# Altium schematic sheet Power.SchDoc
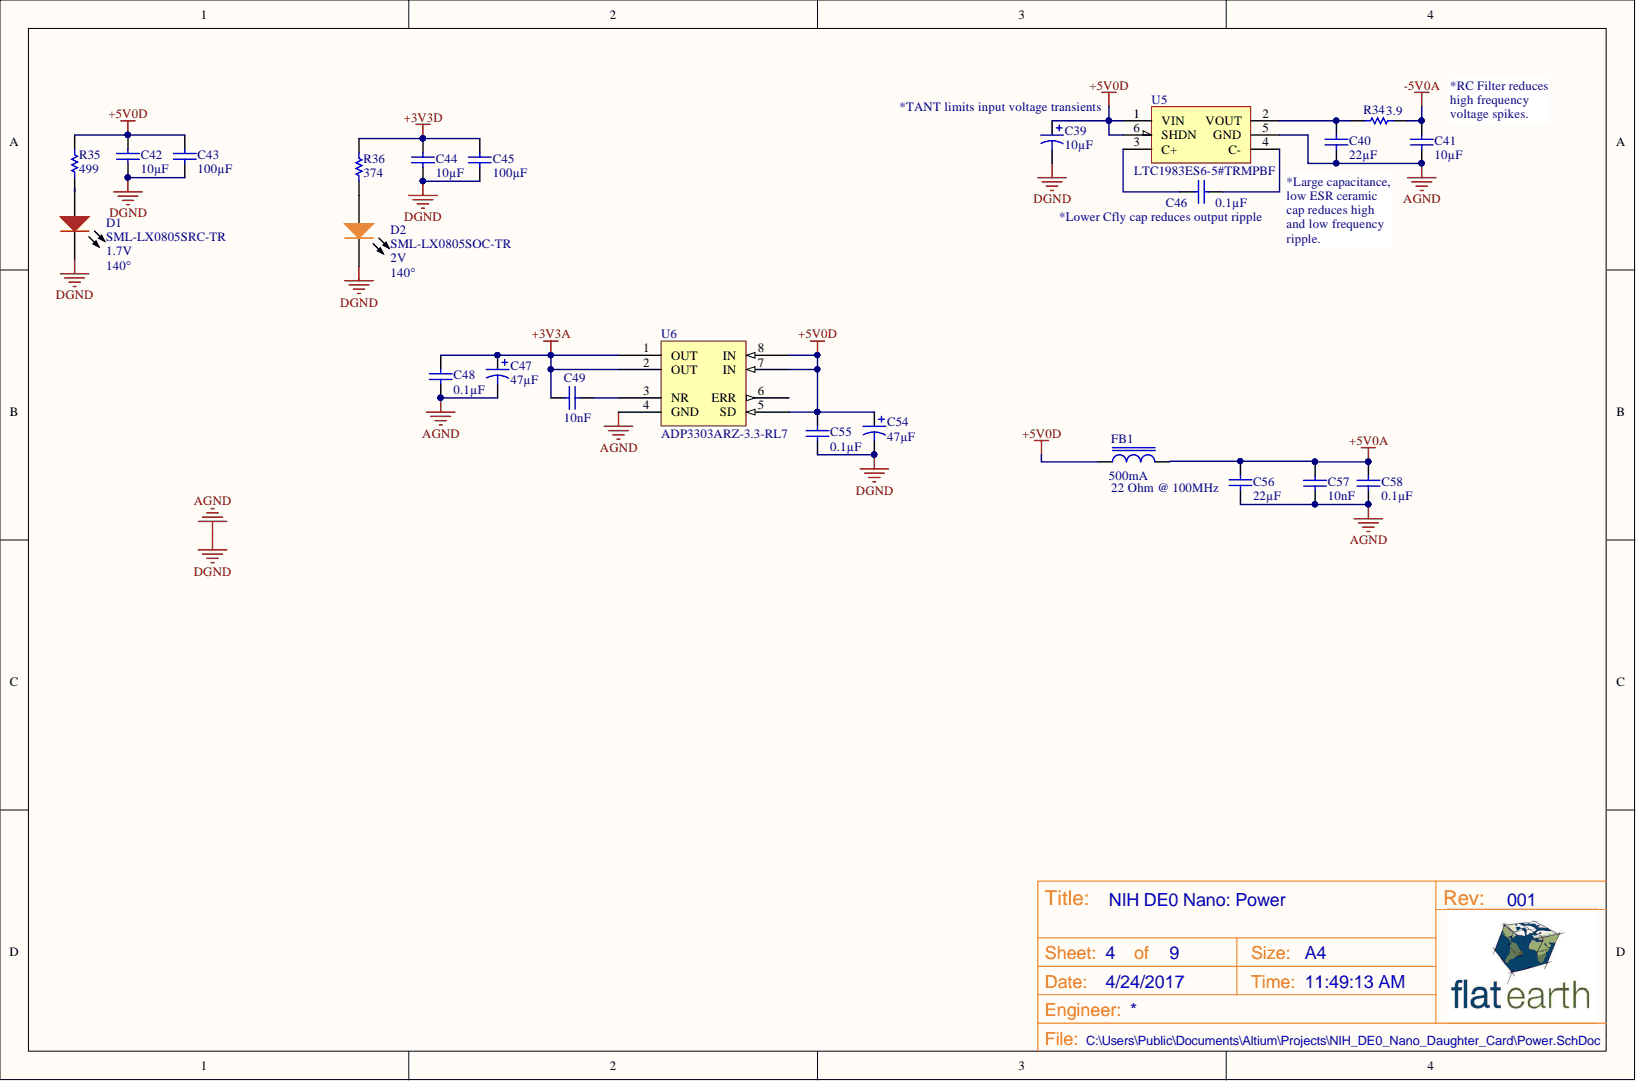
[diagram p0: Power.SchDoc]

## Parts
C39  Capacitance: 10µF  [C1206_TANT]  (hidden comment: TPSA106K010R0900)
C40  Capacitance: 22µF  [C1206]  (hidden comment: C3216X6S1C226M)
C41  Capacitance: 10µF  [3-0603_L]  (hidden comment: C1608X5R1A106K)
C42  Capacitance: 10µF  [3-0603_L]  (hidden comment: C1608X5R1A106K)
C43  Capacitance: 100µF  [C1210X25_L]  (hidden comment: CL32X107MQVNNNE)
C44  Capacitance: 10µF  [3-0603_L]  (hidden comment: C1608X5R1A106K)
C45  Capacitance: 100µF  [C1210X25_L]  (hidden comment: CL32X107MQVNNNE)
C46  Capacitance: 0.1µF  [3-0603_L]  (hidden comment: C1608X7R1H104K)
C47  Capacitance: 47µF  [ALUMCAPC630D_D]  (hidden comment: EEE-FT1H470AP)
C48  Capacitance: 0.1µF  [2-0402_L]  (hidden comment: C1005X7R1H104K)
C49  Capacitance: 10nF  [3-0603_L]  (hidden comment: C1608X7R2A103K080AA)
C54  Capacitance: 47µF  [ALUMCAPC630D_D]  (hidden comment: EEE-FT1H470AP)
C55  Capacitance: 0.1µF  [2-0402_L]  (hidden comment: C1005X7R1H104K)
C56  Capacitance: 22µF  [6-0805_L]  (hidden comment: CL21A226KPCLRNC)
C57  Capacitance: 10nF  [3-0603_L]  (hidden comment: C0603C103J5RACTU)
C58  Capacitance: 0.1µF  [3-0603_L]  (hidden comment: C1608X7R1H104K)
D1  Manufacturer Part Number: SML-LX0805SRC-TR  Viewing Angle: 140°  Voltage - Forward (Vf) (Typ): 1.7V  [DIOM2012X12L_RED]  (hidden comment: LED_RED 4)
D2  Manufacturer Part Number: SML-LX0805SOC-TR  Viewing Angle: 140°  Voltage - Forward (Vf) (Typ): 2V  [DIOM2012X12L_ORANGE]  (hidden comment: LED_ORANGE 3)
FB1  Current Rating: 500mA  [3-0603_L]  (hidden comment: BLM18BA220SN1D)
R34  Resistance (Ohms): 3.9  [3-0603_R_L]  (hidden comment: ERJ-3RQF3R9V)
R35  ERJ-3EKF4990V  [3-0603_R_L]  (value not drawn)
R36  ERJ-3EKF3740V  [3-0603_R_L]  (value not drawn)
U5  SymName  [SOT23-6_L]  (value not drawn)
U6  ADP3303ARZ-3.3-RL7  [SOIC127P600X175-8L]

## Nets
+3V3A: C47.1 C48.2 C49.1 U6.1(OUT) U6.2(OUT)
+3V3D: C44.2 C45.2 R36.2
+5V0A: C56.2 C57.2 C58.2 FB1.2
+5V0D: C39.1 C42.2 C43.2 C54.1 C55.2 FB1.1 R35.2 U5.1(VIN) U5.6(SHDN) U6.5(SD) U6.7(IN) U6.8(IN)
-5V0A: C41.2 R34.2
AGND: C39.2 C40.1 C41.1 C42.1 C43.1 C44.1 C45.1 C47.2 C48.1 C54.2 C55.1 C56.1 C57.1 C58.1 D1.2 D2.2 U5.5(GND) U6.4(GND)
NetC40_2: C40.2 R34.1 U5.2(VOUT)
NetC46_1: C46.1 U5.3(C+)
NetC46_2: C46.2 U5.4(C-)
NetC49_2: C49.2 U6.3(NR)
NetD1_1: D1.1 R35.1
NetD2_1: D2.1 R36.1

Not connected: U6 6(ERR)

## Library data of the parts
C39 = TPSA106K010R0900: CAP TANT 10UF 10V 10% 1206; Manufacturer: AVX Corporation; Manufacturer Size Code: A; ESR (Equivalent Series Resistance): 900 mOhm; Type: Molded; Category: Capacitors; Component Kind: Standard; ComponentLink1Description: http://www.avx.com/docs/Catalogs/tps.pdf; ComponentLink1URL: http://www.avx.com/docs/Catalogs/tps.pdf; Features: General Purpose; Height - Seated (Max): 0.071" (1.80mm); Lead Spacing: -; Library Name: Schematic_Components.SchLib; Lifetime @ Temp.: -; Manufacturer Part Number: TPSA106K010R0900; Mounting Type: Surface Mount; Operating Temperature: -55°C ~ 125°C; Package / Case: 1206 (3216 Metric); Packaging: Cut Tape (CT); Pricing 1: 1=0.77, 10=0.737, 100=0.3575, 250=0.297, 500=0.2695, 1000=0.2475 (USD); RoHS: RoHS Compliant; Size / Dimension: 0.126" L x 0.063" W (3.20mm x 1.60mm); Stock 1: 62197; Supplier 1: Digi-Key; Supplier Part Number 1: 478-1751-1-ND; Tolerance: ±10%; Voltage - Rated: 10V
C40 = C3216X6S1C226M: CAP CER 22UF 16V 20% X6S 1206; Manufacturer: TDK Corporation; Applications: General Purpose; Category: Capacitors; Component Kind: Standard; ComponentLink1Description: http://www.tdk.com/pdf/general_B11.pdf; ComponentLink1URL: http://www.tdk.com/pdf/general_B11.pdf; Features: -; Height - Seated (Max): -; Lead Spacing: -; Lead Style: -; Library Name: Schematic_Components.SchLib; Manufacturer Part Number: C3216X6S1C226M; Mounting Type: Surface Mount, MLCC; Operating Temperature: -55°C ~ 105°C; Package / Case: 1206 (3216 Metric); Packaging: Cut Tape (CT); Pricing 1: 1=1.13, 10=0.94, 100=0.6, 250=0.5, 500=0.45, 1000=0.41 (USD); Ratings: -; RoHS: RoHS Compliant; Size / Dimension: 0.126" L x 0.063" W (3.20mm x 1.60mm); Stock 1: 4138; Supplier 1: Digi-Key; Supplier Part Number 1: 445-8044-1-ND; Temperature Coefficient: X6S; Thickness (Max): -; Tolerance: ±20%; Voltage - Rated: 16V
C41 C42 C44 = C1608X5R1A106K: CAP CER 10UF 10V 10% X5R 0603; Manufacturer: TDK Corporation; Applications: General Purpose; Category: Capacitors; Component Kind: Standard; ComponentLink1Description: http://www.tdk.com/pdf/general_B11.pdf; ComponentLink1URL: http://www.tdk.com/pdf/general_B11.pdf; Features: -; Height - Seated (Max): -; Lead Spacing: -; Lead Style: -; Library Name: Schematic_Components.SchLib; Manufacturer Part Number: C1608X5R1A106K; Mounting Type: Surface Mount, MLCC; Operating Temperature: -55°C ~ 85°C; Package / Case: 0603 (1608 Metric); Packaging: Cut Tape (CT); Pricing 1: 1=0.48, 10=0.42, 100=0.21, 250=0.168, 500=0.153, 1000=0.138 (USD); Ratings: -; RoHS: RoHS Compliant; Size / Dimension: 0.063" L x 0.031" W (1.60mm x 0.80mm); Stock 1: 36523; Supplier 1: Digi-Key; Supplier Part Number 1: 445-7486-1-ND; Temperature Coefficient: X5R; Thickness (Max): 0.035" (0.90mm); Tolerance: ±10%; Voltage - Rated: 10V
C43 C45 = CL32X107MQVNNNE: 100µF 6.3V Ceramic Capacitor X6S 1210 (3225 Metric) 0.126" L x 0.098" W (3.20mm x 2.50mm); Manufacturer: Samsung Electro-Mechanics America, Inc.; Tolerance: ±20%; Applications: General Purpose; Category: Capacitors; Component Kind: Standard; ComponentLink1Description: http://www.samsungsem.com/kr/front/downloadcms.do?path=/kr/support/product-search/mlcc/__icsFiles/afieldfile/2014/11/05&fileName=C_CL32X107MQVNNNE.pdf; ComponentLink1URL: http://www.samsungsem.com/kr/front/downloadcms.do?path=/kr/support/product-search/mlcc/__icsFiles/afieldfile/2014/11/05&fileName=C_CL32X107MQVNNNE.pdf; Features: -; Height - Seated (Max): -; Lead Spacing: -; Lead Style: -; Library Name: Schematic_Components.SchLib; Manufacturer Part Number: CL32X107MQVNNNE; Mounting Type: Surface Mount, MLCC; Operating Temperature: -55°C ~ 105°C; Package / Case: 1210 (3225 Metric); Packaging: Cut Tape (CT); Part Status: Active; Pricing 1: 1=1.35, 10=1.13, 25=0.974, 50=0.914, 100=0.7215, 500=0.54112 (USD); Ratings: -; RoHS: RoHS Compliant; Size / Dimension: 0.126" L x 0.098" W (3.20mm x 2.50mm); Stock 1: 19666; Supplier 1: Digi-Key; Supplier Part Number 1: 1276-3399-1-ND; Temperature Coefficient: X6S; Thickness (Max): 0.110" (2.80mm); Voltage - Rated: 6.3V
C46 C58 = C1608X7R1H104K: CAP CER 0.1UF 50V 10% X7R 0603; Manufacturer: TDK Corporation; Applications: General Purpose; Category: Capacitors; Component Kind: Standard; ComponentLink1Description: http://www.tdk.com/pdf/general_B11.pdf; ComponentLink1URL: http://www.tdk.com/pdf/general_B11.pdf; Features: -; Height - Seated (Max): -; Lead Spacing: -; Lead Style: -; Library Name: Schematic_Components.SchLib; Manufacturer Part Number: C1608X7R1H104K; Mounting Type: Surface Mount, MLCC; Operating Temperature: -55°C ~ 125°C; Package / Case: 0603 (1608 Metric); Packaging: Cut Tape (CT); Pricing 1: 10=0.048, 100=0.022, 250=0.018, 500=0.0154, 1000=0.012 (USD); Ratings: -; RoHS: RoHS Compliant; Size / Dimension: 0.063" L x 0.031" W (1.60mm x 0.80mm); Stock 1: 2442296; Supplier 1: Digi-Key; Supplier Part Number 1: 445-1314-1-ND; Temperature Coefficient: X7R; Thickness (Max): 0.037" (0.95mm); Tolerance: ±10%; Voltage - Rated: 50V
C47 C54 = EEE-FT1H470AP: CAP ALUM 47UF 50V 20% SMD; Manufacturer: Panasonic - ECG; ESR (Equivalent Series Resistance): 680.0 mOhm; Lifetime @ Temp.: 2000 Hrs @ 105°C; Ripple Current: 195mA; Surface Mount Land Size: 0.260" L x 0.260" W (6.60mm x 6.60mm); Category: Capacitors; Component Kind: Standard; ComponentLink1Description: http://industrial.panasonic.com/www-cgi/jvcr17pz.cgi?E+PZ+4+ABA0134+0+WW; ComponentLink1URL: http://industrial.panasonic.com/www-cgi/jvcr17pz.cgi?E+PZ+4+ABA0134+0+WW; Features: General Purpose; Height - Seated (Max): 0.240" (6.10mm); Impedance: -; Lead Spacing: -; Library Name: Schematic_Components.SchLib; Manufacturer Part Number: EEE-FT1H470AP; Mounting Type: Surface Mount; Operating Temperature: -55°C ~ 105°C; Package / Case: Radial, Can - SMD; Packaging: Cut Tape (CT); Pricing 1: 1=0.81, 50=0.4834, 100=0.4229, 250=0.33232, 500=0.3021 (USD); RoHS: RoHS Compliant; Size / Dimension: 0.248" Dia (6.30mm); Stock 1: 1772; Supplier 1: Digi-Key; Supplier Part Number 1: PCE5023CT-ND; Tolerance: ±20%; Voltage Rating: 50V
C48 C55 = C1005X7R1H104K: CAP CER 0.1UF 50V 10% X7R 0402; Manufacturer: TDK Corporation; Applications: General Purpose; Category: Capacitors; Component Kind: Standard; ComponentLink1Description: http://www.tdk.com/pdf/general_B11.pdf; ComponentLink1URL: http://www.tdk.com/pdf/general_B11.pdf; Features: -; Height - Seated (Max): -; Lead Spacing: -; Lead Style: -; Library Name: Schematic_Components.SchLib; Manufacturer Part Number: C1005X7R1H104K; Mounting Type: Surface Mount, MLCC; Operating Temperature: -55°C ~ 125°C; Package / Case: 0402 (1005 Metric); Packaging: Cut Tape (CT); Pricing 1: 1=0.15, 10=0.1, 100=0.0475, 250=0.04, 500=0.0335, 1000=0.0275, 5000=0.02325 (USD); Ratings: -; RoHS: RoHS Compliant; Size / Dimension: 0.039" L x 0.020" W (1.00mm x 0.50mm); Stock 1: 319723; Supplier 1: Digi-Key; Supplier Part Number 1: 445-5932-1-ND; Temperature Coefficient: X7R; Thickness (Max): 0.022" (0.55mm); Tolerance: ±10%; Voltage - Rated: 50V
C49 = C1608X7R2A103K080AA: CAP CER 10000PF 100V X7R 0603; Manufacturer: TDK Corporation; Tolerance: ±10%; Applications: General Purpose; Category: Capacitors; Component Kind: Standard; ComponentLink1Description: http://product.tdk.com/capacitor/mlcc/en/documents/mlcc_commercial_midvoltage_en.pdf; ComponentLink1URL: http://product.tdk.com/capacitor/mlcc/en/documents/mlcc_commercial_midvoltage_en.pdf; Features: -; Height - Seated (Max): -; Lead Spacing: -; Lead Style: -; Library Name: Schematic_Components.SchLib; Manufacturer Part Number: C1608X7R2A103K080AA; Mounting Type: Surface Mount, MLCC; Operating Temperature: -55°C ~ 125°C; Package / Case: 0603 (1608 Metric); Packaging: Cut Tape (CT); Pricing 1: 1=0.1, 10=0.06, 100=0.0275, 250=0.02252, 500=0.01926, 1000=0.015 (USD); Ratings: -; RoHS: RoHS Compliant; Size / Dimension: 0.063" L x 0.031" W (1.60mm x 0.80mm); Stock 1: 257721; Supplier 1: Digi-Key; Supplier Part Number 1: 445-1304-1-ND; Temperature Coefficient: X7R; Thickness (Max): 0.037" (0.95mm); Voltage - Rated: 100V
C56 = CL21A226KPCLRNC: CAP CER 22UF 10V X5R 0805; Manufacturer: Samsung Electro-Mechanics America, Inc.; Tolerance: ±10%; Applications: General Purpose; Category: Capacitors; Component Kind: Standard; ComponentLink1Description: http://www.samsungsem.com/kr/support/product-search/mlcc/__icsFiles/afieldfile/2016/08/18/S_CL21A226KPCLRNC.pdf; ComponentLink1URL: http://www.samsungsem.com/kr/support/product-search/mlcc/__icsFiles/afieldfile/2016/08/18/S_CL21A226KPCLRNC.pdf; Features: Low Profile; Height - Seated (Max): -; Lead Spacing: -; Lead Style: -; Library Name: Schematic_Components.SchLib; Manufacturer Part Number: CL21A226KPCLRNC; Mounting Type: Surface Mount, MLCC; Operating Temperature: -55°C ~ 85°C; Package / Case: 0805 (2012 Metric); Packaging: Cut Tape (CT); Part Status: Active; Pricing 1: 1=0.25, 10=0.173, 25=0.1384, 50=0.1154, 100=0.0981, 250=0.07788, 500=0.06924, 1000=0.06059 (USD); Ratings: -; RoHS: RoHS Compliant; Size / Dimension: 0.079" L x 0.049" W (2.00mm x 1.25mm); Stock 1: 70317; Supplier 1: Digi-Key; Supplier Part Number 1: 1276-6786-1-ND; Temperature Coefficient: X5R; Thickness (Max): 0.037" (0.95mm); Voltage - Rated: 10V
C57 = C0603C103J5RACTU: CAP CER 10000PF 50V 5% X7R 0603; Manufacturer: Kemet; Applications: General Purpose; Category: Capacitors; Component Kind: Standard; ComponentLink1Description: http://www.kemet.com/datasheets&C0603C103J5RACTU; ComponentLink1URL: http://www.kemet.com/datasheets&C0603C103J5RACTU; Features: -; Height - Seated (Max): -; Lead Spacing: -; Lead Style: -; Library Name: Schematic_Components.SchLib; Manufacturer Part Number: C0603C103J5RACTU; Mounting Type: Surface Mount, MLCC; Operating Temperature: -55°C ~ 125°C; Package / Case: 0603 (1608 Metric); Packaging: Cut Tape (CT); Pricing 1: 1=0.1, 10=0.036, 50=0.0196, 100=0.0165, 250=0.01352, 500=0.01156, 1000=0.009 (USD); Ratings: -; RoHS: RoHS Compliant; Size / Dimension: 0.063" L x 0.031" W (1.60mm x 0.80mm); Stock 1: 187680; Supplier 1: Digi-Key; Supplier Part Number 1: 399-1092-1-ND; Temperature Coefficient: X7R; Thickness (Max): 0.034" (0.87mm); Tolerance: ±5%; Voltage - Rated: 50V
D1 = LED_RED 4: LED 660NM SUPER RED CLR 0805 T/R; Manufacturer: Lumex Opto/Components Inc; Current - Test: 20mA; Lens Type: Clear; Millicandela Rating: 25mcd; Category: Optoelectronics; Color: Red; Component Kind: Standard; ComponentLink1Description: http://www.lumex.com/specs/SML-LX0805SRC-TR.pdf; ComponentLink1URL: http://www.lumex.com/specs/SML-LX0805SRC-TR.pdf; Height: 1.10mm; Library Name: Schematic_Components.SchLib; Luminous Flux @ Current - Test: -; Mounting Type: Surface Mount; Package / Case: 0805 (2012 Metric); Packaging: Cut Tape (CT); Pricing 1: 1=0.56, 10=0.395, 100=0.2596, 250=0.19472, 500=0.177, 1000=0.1416 (USD); RoHS: RoHS Compliant; Size / Dimension: 2.00mm L x 1.25mm W; Stock 1: 1141; Supplier 1: Digi-Key; Supplier Part Number 1: 67-2191-1-ND; Wavelength - Dominant: -; Wavelength - Peak: 660nm
D2 = LED_ORANGE 3: LED 610NM SUPER ORANGE 0805 SMD; Manufacturer: Lumex Opto/Components Inc; Current - Test: 20mA; Lens Style/Size: Rectangle with Flat Top, 1.4mm x 1.25mm; Lens Type: Clear; Millicandela Rating: 60mcd; Category: Optoelectronics; Color: Orange; Component Kind: Standard; ComponentLink1Description: http://www.lumex.com/specs/SML-LX0805SOC-TR.pdf; ComponentLink1URL: http://www.lumex.com/specs/SML-LX0805SOC-TR.pdf; Height: 1.10mm; Library Name: Schematic_Components.SchLib; Luminous Flux @ Current - Test: -; Mounting Type: Surface Mount; Package / Case: 0805 (2012 Metric); Packaging: Cut Tape (CT); Pricing 1: 1=0.65, 10=0.502, 100=0.3255, 250=0.279, 500=0.2418, 1000=0.186 (USD); RoHS: RoHS Compliant; Size / Dimension: 2.00mm L x 1.25mm W; Stock 1: 13373; Supplier 1: Digi-Key; Supplier Part Number 1: 67-1637-1-ND; Wavelength - Dominant: -; Wavelength - Peak: 610nm
FB1 = BLM18BA220SN1D: FERRITE CHIP 22 OHM 500MA 0603; Manufacturer: Murata Electronics North America; DC Resistance (DCR): 350 mOhm Max; Filter Type: Differential Mode - Single; Impedance @ Frequency: 22 Ohm @ 100MHz; Category: Filters; Component Kind: Standard; ComponentLink1Description: http://search.murata.co.jp/Ceramy/image/img/PDF/ENG/L0110S0100BLM18B.pdf; ComponentLink1URL: http://search.murata.co.jp/Ceramy/image/img/PDF/ENG/L0110S0100BLM18B.pdf; Height (Max): 0.037" (0.95mm); Library Name: Schematic_Components.SchLib; Manufacturer Part Number: BLM18BA220SN1D; Mounting Type: Surface Mount; Package / Case: 0603 (1608 Metric); Packaging: Cut Tape (CT); Pricing 1: 1=0.24, 10=0.18, 50=0.105, 100=0.084, 250=0.06, 500=0.0408, 1000=0.033 (USD); RoHS: RoHS Compliant; Size / Dimension: 0.063" L x 0.031" W (1.60mm x 0.80mm); Stock 1: 10969; Supplier 1: Digi-Key; Supplier Part Number 1: 490-1016-1-ND
R34 = ERJ-3RQF3R9V: RES 3.9 OHM 1/10W 1% 0603 SMD; Manufacturer: Panasonic Electronic Components; Power (Watts): 0.1W, 1/10W; Temperature Coefficient: ±200ppm/°C; Category: Resistors; Component Kind: Standard; ComponentLink1Description: http://industrial.panasonic.com/www-cgi/jvcr13pz.cgi?E+PZ+3+AOA0003+ERJ3RQF3R9V+7+WW; ComponentLink1URL: http://industrial.panasonic.com/www-cgi/jvcr13pz.cgi?E+PZ+3+AOA0003+ERJ3RQF3R9V+7+WW; Composition: Thick Film; Features: -; Height: 0.022" (0.55mm); Library Name: Schematic_Components.SchLib; Manufacturer Part Number: ERJ-3RQF3R9V; Number of Terminations: 2; Package / Case: 0603 (1608 Metric); Packaging: Cut Tape (CT); Pricing 1: 1=0.29, 50=0.1138, 100=0.0878, 250=0.06824, 500=0.0481, 1000=0.0351 (USD); RoHS: RoHS Compliant; Size / Dimension: 0.063" L x 0.031" W (1.60mm x 0.80mm); Stock 1: 44573; Supplier 1: Digi-Key; Supplier Device Package: 0603; Supplier Part Number 1: P3.9AJCT-ND; Tolerance: ±1%
R35 = ERJ-3EKF4990V: RES 499 OHM 1/10W 1% 0603 SMD; Manufacturer: Panasonic - ECG; Power (Watts): 0.1W, 1/10W; Resistance (Ohms): 499; Temperature Coefficient: ±100ppm/°C; Category: Resistors; Component Kind: Standard; ComponentLink1Description: http://industrial.panasonic.com/www-cgi/jvcr13pz.cgi?E+PZ+3+AOA0002+ERJ3EKF4990V+7+WW; ComponentLink1URL: http://industrial.panasonic.com/www-cgi/jvcr13pz.cgi?E+PZ+3+AOA0002+ERJ3EKF4990V+7+WW; Composition: Thick Film; Features: -; Height: 0.022" (0.55mm); Library Name: Schematic_Components.SchLib; Manufacturer Part Number: ERJ-3EKF4990V; Number of Terminations: 2; Package / Case: 0603 (1608 Metric); Packaging: Cut Tape (CT); Pricing 1: 1=0.1, 50=0.0138, 100=0.0105, 250=0.0078, 500=0.00576, 1000=0.00384 (USD); RoHS: RoHS Compliant; Size / Dimension: 0.063" L x 0.031" W (1.60mm x 0.80mm); Stock 1: 748630; Supplier 1: Digi-Key; Supplier Part Number 1: P499HCT-ND; Tolerance: ±1%
R36 = ERJ-3EKF3740V: RES SMD 374 OHM 1% 1/10W 0603; Manufacturer: Panasonic Electronic Components; Power (Watts): 0.1W, 1/10W; Resistance (Ohms): 374; Temperature Coefficient: ±100ppm/°C; Category: Resistors; Component Kind: Standard; ComponentLink1Description: http://industrial.panasonic.com/www-cgi/jvcr13pz.cgi?E+PZ+3+AOA0002+ERJ3EKF3740V+7+WW; ComponentLink1URL: http://industrial.panasonic.com/www-cgi/jvcr13pz.cgi?E+PZ+3+AOA0002+ERJ3EKF3740V+7+WW; Composition: Thick Film; Features: Automotive AEC-Q200; Height: 0.022" (0.55mm); Library Name: Schematic_Components.SchLib; Manufacturer Part Number: ERJ-3EKF3740V; Number of Terminations: 2; Operating Temperature: -55°C ~ 155°C; Package / Case: 0603 (1608 Metric); Packaging: Cut Tape (CT); Part Status: Active; Pricing 1: 1=0.1, 50=0.0114, 100=0.0084, 250=0.00644, 500=0.00514, 1000=0.00379 (USD); RoHS: RoHS Compliant; Size / Dimension: 0.063" L x 0.031" W (1.60mm x 0.80mm); Stock 1: 48031; Supplier 1: Digi-Key; Supplier Device Package: 0603; Supplier Part Number 1: P374HCT-ND; Tolerance: ±1%
U5 = LTC1983ES6-5#TRMPBF: IC REG SWITCHD CAP INV -5V SOT23; Manufacturer: Linear Technology; Current - Output: 100mA; Frequency - Switching: 900kHz; Number of Outputs: 1; Output Type: Fixed; PWM Type: Burst Mode®; Synchronous Rectifier: No; Voltage - Input: 2.3 V ~ 5.5 V; Category: Integrated Circuits (ICs); Component Kind: Standard; ComponentLink1Description: http://www.linear.com/docs/2135; ComponentLink1URL: http://www.linear.com/docs/2135; Library Name: Schematic_Components.SchLib; Manufacturer Part Number: LTC1983ES6-5#TRMPBF; Mounting Type: Surface Mount; Operating Temperature: -40°C ~ 85°C; Package / Case: SOT-23-6 Thin, TSOT-23-6; Packaging: Cut Tape (CT); Pricing 1: 1=4.28, 25=3.8192, 100=3.4371 (USD); RoHS: RoHS Compliant; Stock 1: 6466; Supplier 1: Digi-Key; Supplier Device Package: TSOT-23-6; Supplier Part Number 1: LTC1983ES6-5#TRMPBFCT-ND; Type: Switched Capacitor (Charge Pump), Inverting; Voltage - Output: -5V
U6 = ADP3303ARZ-3.3-RL7: Linear Voltage Regulator IC Positive Fixed 1 Output 3.3V 200mA 8-SOIC; Manufacturer: Analog Devices Inc.; Number of Regulators: 1; Voltage - Dropout (Typical): 0.18V @ 200mA; Voltage - Input: Up to 12V; Category: Integrated Circuits (ICs); Component Kind: Standard; ComponentLink1Description: http://www.analog.com/media/en/technical-documentation/data-sheets/ADP3303.pdf; ComponentLink1URL: http://www.analog.com/media/en/technical-documentation/data-sheets/ADP3303.pdf; Current - Limit (Min): -; Current - Output: 200mA; Manufacturer Part Number: ADP3303ARZ-3.3-RL7; Mounting Type: Surface Mount; Operating Temperature: -25°C ~ 125°C; Package / Case: 8-SOIC (0.154", 3.90mm Width); Packaging: Cut Tape (CT); Part Status: Active; Pricing 1: 1=3.19, 10=2.867, 100=2.3517, 500=2.0007 (USD); Regulator Topology: Positive Fixed; RoHS: RoHS Compliant; Stock 1: 2012; Supplier 1: Digi-Key; Supplier Device Package: 8-SOIC; Supplier Part Number 1: ADP3303ARZ-3.3-RL7CT-ND; Voltage - Output: 3.3V; Library Name: NIH_DE0_Nano_Daughter_Card_Library.SchLib
